# Altium schematic sheet SCM20260D-Ethernet.SchDoc
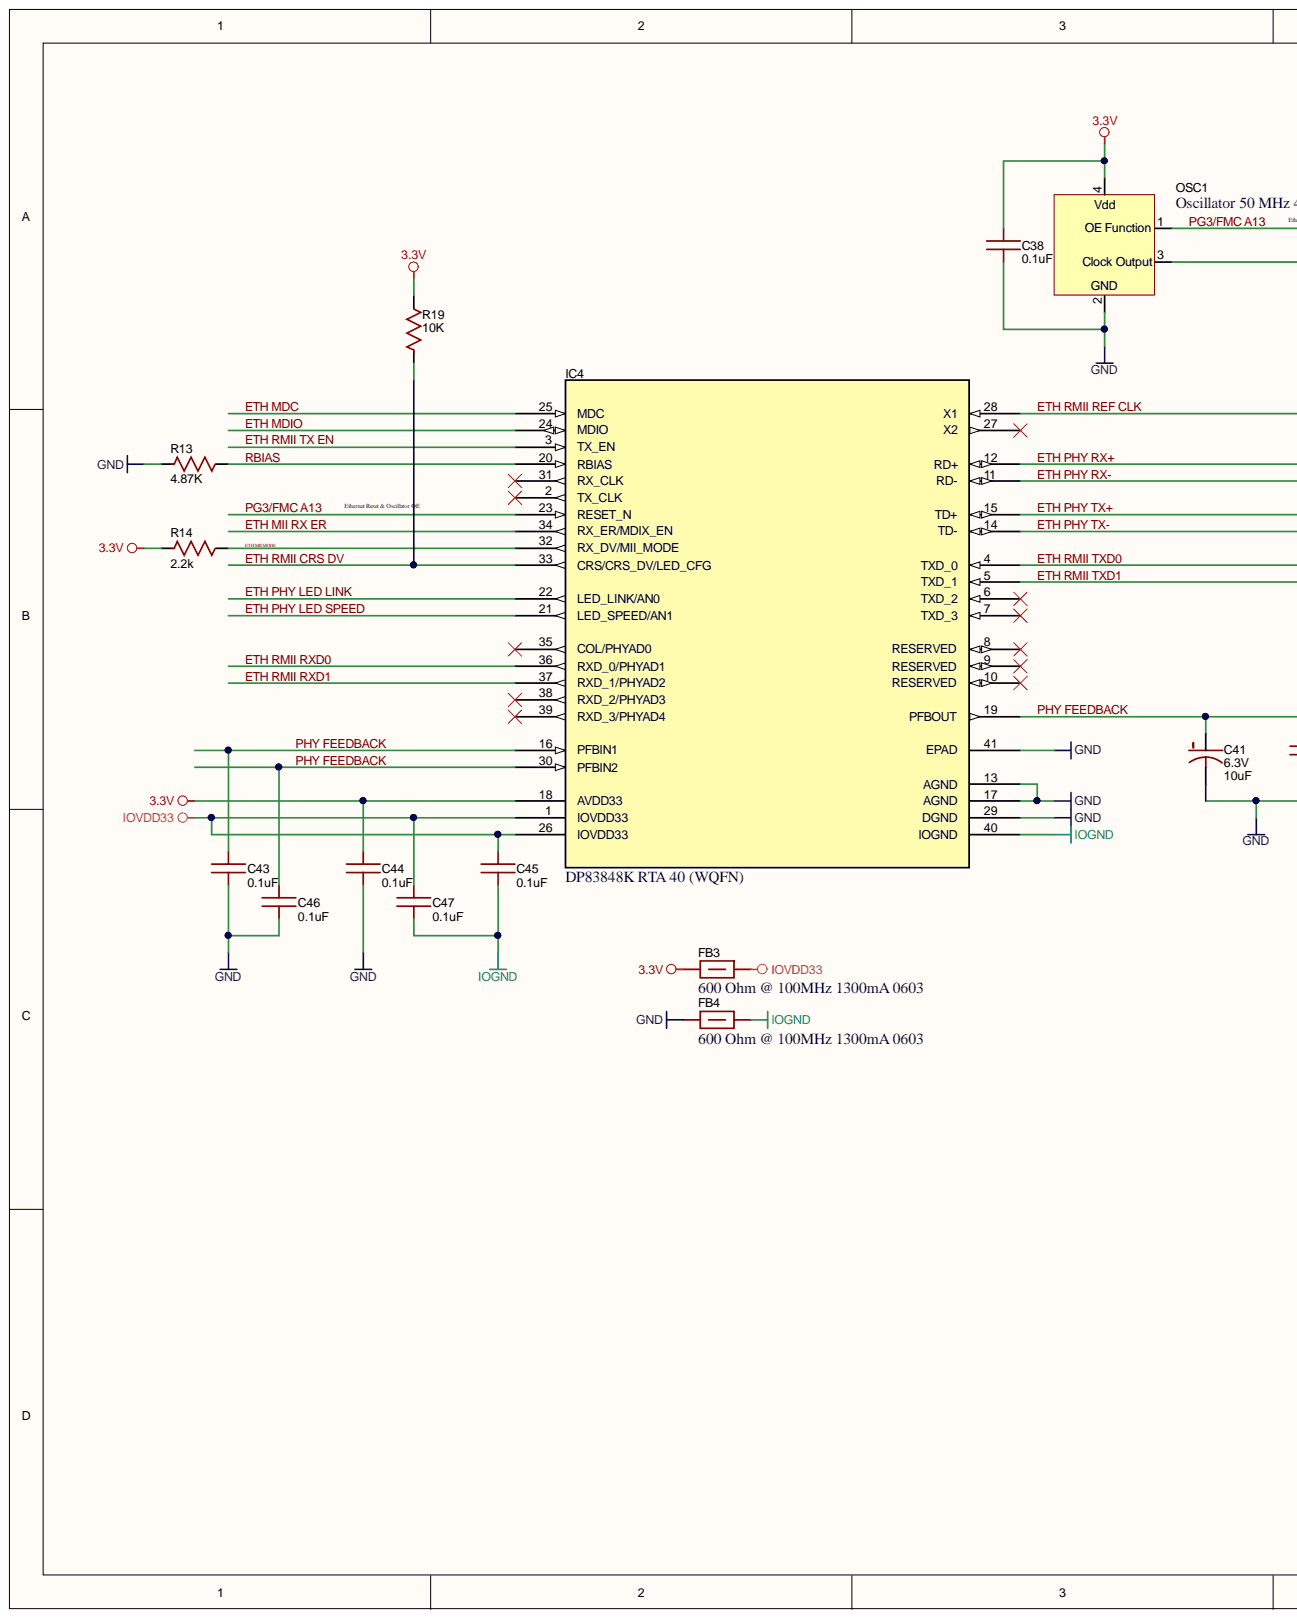
[diagram p0: SCM20260D-Ethernet.SchDoc, left]
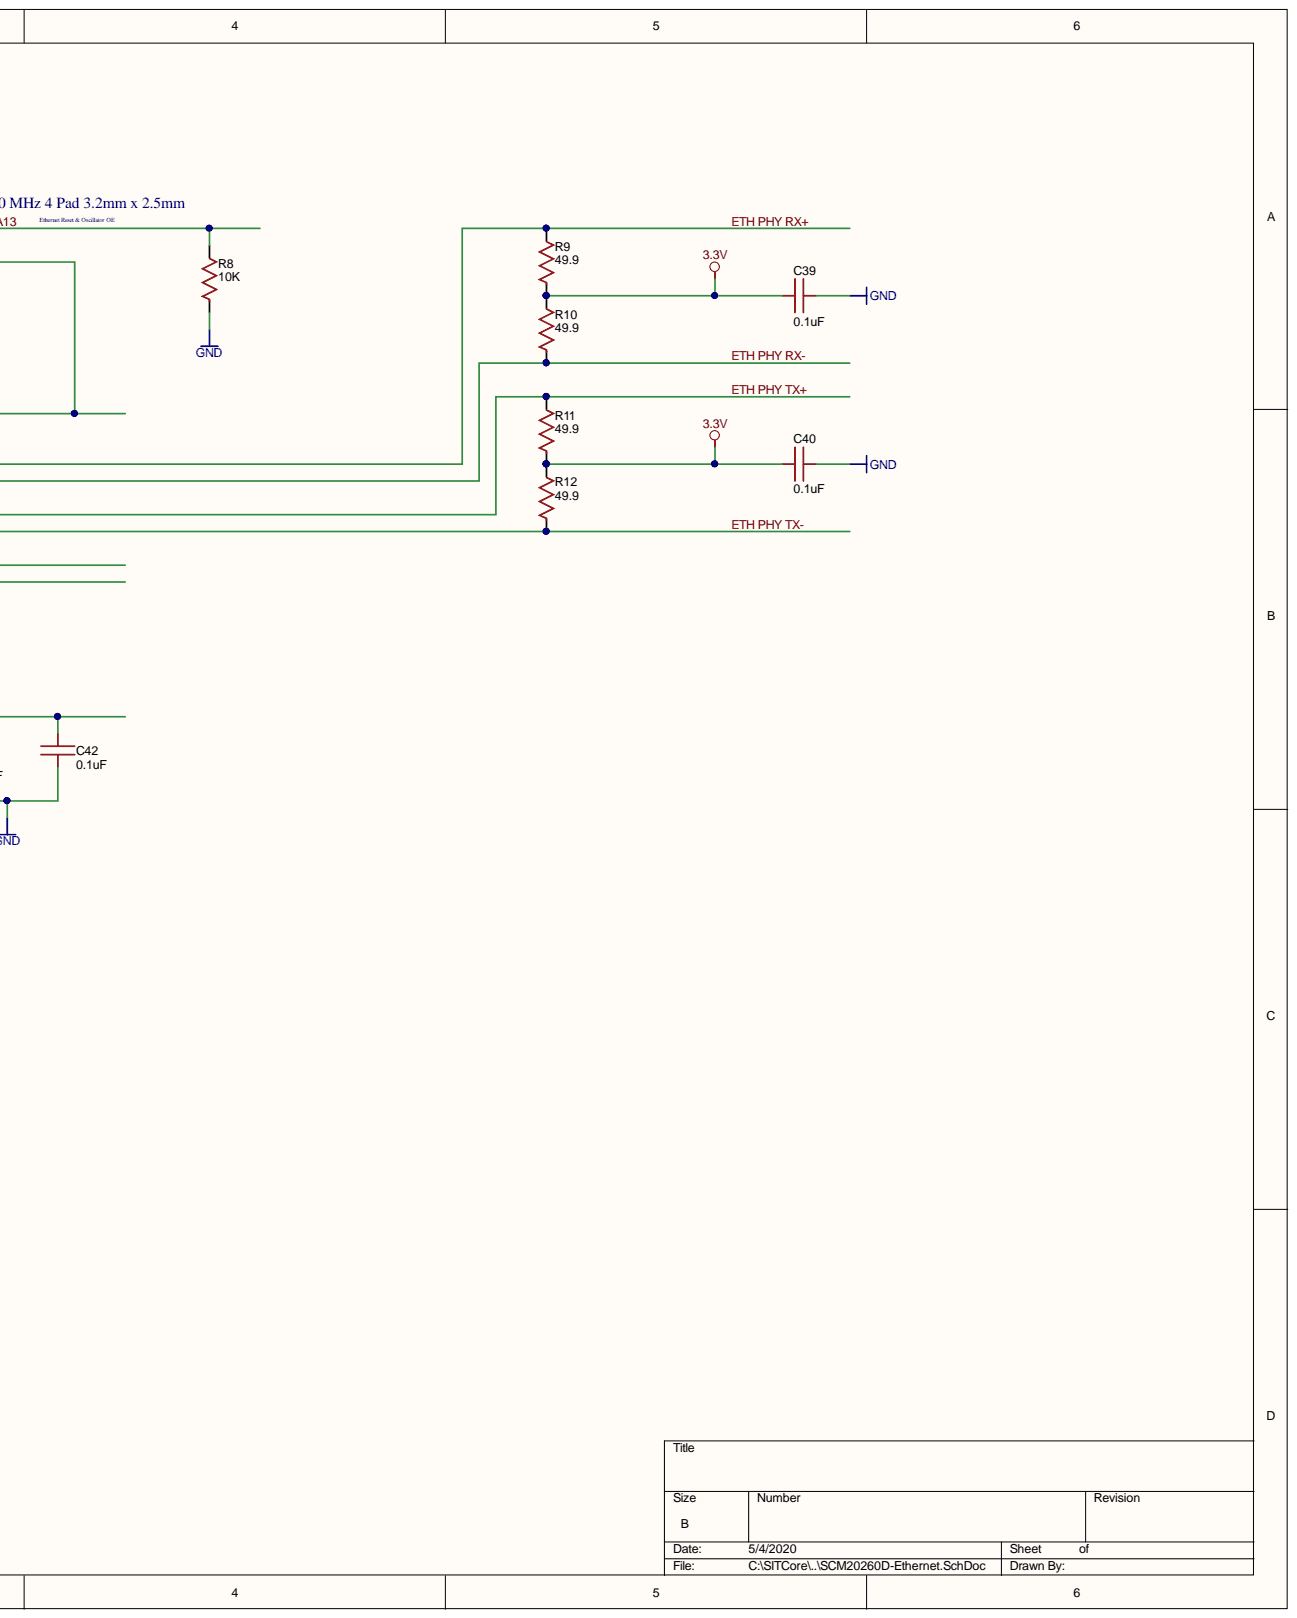
[diagram p1: SCM20260D-Ethernet.SchDoc, right]

## Parts
C38  value 0.1uF  Capacitance: 0.1uF  [C0402]  (hidden comment: 0402 Cap 0.1uF)
C39  value 0.1uF  Capacitance: 0.1uF  [C0402]  (hidden comment: 0402 Cap 0.1uF)
C40  value 0.1uF  Capacitance: 0.1uF  [C0402]  (hidden comment: 0402 Cap 0.1uF)
C41  value 10uF  Capacitance: 10uF  Voltage: 6.3V  [CASE-R 0805 (2012)]  (hidden comment: CASE-R 0805 (2012) Tant 10uF)
C42  value 0.1uF  Capacitance: 0.1uF  [C0402]  (hidden comment: 0402 Cap 0.1uF)
C43  value 0.1uF  Capacitance: 0.1uF  [C0402]  (hidden comment: 0402 Cap 0.1uF)
C44  value 0.1uF  Capacitance: 0.1uF  [C0402]  (hidden comment: 0402 Cap 0.1uF)
C45  value 0.1uF  Capacitance: 0.1uF  [C0402]  (hidden comment: 0402 Cap 0.1uF)
C46  value 0.1uF  Capacitance: 0.1uF  [C0402]  (hidden comment: 0402 Cap 0.1uF)
C47  value 0.1uF  Capacitance: 0.1uF  [C0402]  (hidden comment: 0402 Cap 0.1uF)
FB3  600 Ohm @ 100MHz 1300mA 0603  [R0603 (Metric 1608)]
FB4  600 Ohm @ 100MHz 1300mA 0603  [R0603 (Metric 1608)]
IC4  DP83848K RTA 40 (WQFN)  [WQFN 40 (TI-RTA-40)]
OSC1  Oscillator 50 MHz 4 Pad 3.2mm x 2.5mm  [Crystal 3.2mm x 2.5mm]
R8  value 10K  Resistance: 10K  [R0402]  (hidden comment: 0402 Res 10K)
R9  value 49.9  Resistance: 49.9  [R0402]  (hidden comment: 0402 Res 49.9)
R10  value 49.9  Resistance: 49.9  [R0402]  (hidden comment: 0402 Res 49.9)
R11  value 49.9  Resistance: 49.9  [R0402]  (hidden comment: 0402 Res 49.9)
R12  value 49.9  Resistance: 49.9  [R0402]  (hidden comment: 0402 Res 49.9)
R13  value 4.87K  Resistance: 4.87K  [R0402]  (hidden comment: 0402 Res 4.87K)
R14  value 2.2k  Resistance: 2.2k  [R0402]  (hidden comment: 0402 Res 2.2k)
R19  value 10K  Resistance: 10K  [R0402]  (hidden comment: 0402 Res 10K)

## Nets
3.3V: C38.2 C39.1 C40.1 C44.2 FB3.2 IC4.18(AVDD33) OSC1.4 R9.1 R10.2 R11.1 R12.2 R14.1 R19.2
GND: C38.1 C39.2 C40.2 C41.2 C42.1 C43.1 C44.1 C46.1 FB4.2 IC4.13(AGND) IC4.17(AGND) IC4.29(DGND) IC4.41(EPAD) OSC1.2 R8.1 R13.1
IOGND: C45.1 C47.1 FB4.1 IC4.40(IOGND)
IOVDD33: C45.2 C47.2 FB3.1 IC4.1(IOVDD33) IC4.26(IOVDD33)
ETH MDC: IC4.25(MDC)
ETH MDIO: IC4.24(MDIO)
ETH MII MODE: IC4.32(RX_DV/MII_MODE) R14.2
ETH MII RX ER: IC4.34(RX_ER/MDIX_EN)
ETH PHY LED LINK: IC4.22(LED_LINK/AN0)
ETH PHY LED SPEED: IC4.21(LED_SPEED/AN1)
ETH PHY RX+: IC4.12(RD+) R9.2
ETH PHY RX-: IC4.11(RD-) R10.1
ETH PHY TX+: IC4.15(TD+) R11.2
ETH PHY TX-: IC4.14(TD-) R12.1
ETH RMII CRS DV: IC4.33(CRS/CRS_DV/LED_CFG) R19.1
ETH RMII REF CLK: IC4.28(X1) OSC1.3(Clock_Output)
ETH RMII RXD0: IC4.36(RXD_0/PHYAD1)
ETH RMII RXD1: IC4.37(RXD_1/PHYAD2)
ETH RMII TX EN: IC4.3(TX_EN)
ETH RMII TXD0: IC4.4(TXD_0)
ETH RMII TXD1: IC4.5(TXD_1)
PG3/FMC A13: IC4.23(RESET_N) OSC1.1(OE_Function) R8.2
PHY FEEDBACK: C41.1 C42.2 C43.2 C46.2 IC4.16(PFBIN1) IC4.19(PFBOUT) IC4.30(PFBIN2)
RBIAS: IC4.20(RBIAS) R13.2

Not connected: IC4 2(TX_CLK) 6(TXD_2) 7(TXD_3) 8(RESERVED) 9(RESERVED) 10(RESERVED) 27(X2) 31(RX_CLK) 35(COL/PHYAD0) 38(RXD_2/PHYAD3) 39(RXD_3/PHYAD4)

## Library data of the parts
C38-C40 C42-C47 = 0402 Cap 0.1uF: 0.1uF ±10% 16V X7R 0402 Multilayer Ceramic Capacitors MLCC - SMD/SMT RoHS; Mfr.Part #: CC0402KRX7R7BB104; Category: Ceramic; Component Kind: Standard; LCSC Part #: C60474; Package: 0402; Tolerance: ±10%; Voltage: 16V; Library Name: GHI-Passives.SchLib
C41 = CASE-R 0805 (2012) Tant 10uF: 10uF ±10% 6.3V 6 Ω @ 100kHz -55℃ ~ +125℃ CASE-R_2012 Tantalum Capacitors RoHS; Manufacturer: AVX; Mfr.Part #: TAJR106K006RNJ; Category: Tantalum; Component Kind: Standard; Digikey: 478-3273-2-ND; LCSC Part #: C132274; Package: CASE-N_2012; Tolerance: ±10%; Library Name: GHI-Passives.SchLib
FB3 FB4 = 600 Ohm @ 100MHz 1300mA 0603 (Metric 1608): Ferrite Bead 600 Ohm @ 100MHz 1300mA 0603 (Metric 1608); GHI Part Number: x; Manufacturer Part Number: BLM18KG601SN1D; DC Resistance: 150 mOhm; Impedance @ 100MHz: 600+-25%; Rated Current (mA @ 85C): 1300; Rated Current (mA @ 125C): 1000; Component Kind: Standard; Component Type: Ferrite Bead; Library Name: GHI Basic Components.SchLib; Package: 0603
IC4 = DP83848K RTA 40 (WQFN): TI DP83848K 10/100 Ethernet PHY; Manufacturer Part Number: dp8384k_rta_40; Vendor: Texas Instruments; Component Kind: Standard; RefDes: RefDes; Type: Type; Value: Value; Library Name: GHI IC.SchLib
OSC1 = 50.0000MHz 4 Pad Oscillator 3.2mm x 2.5mm: Oscillator 4 Pad 3.2mm x 2.5mm 50 MHz; GHI Part Number: EPC-Q50MHZ-EMX; Manufacturer Part Number: 6034050000M1503033451; Fan Out Type: TTL/CMOS; Frequency Stability: 50ppm; Output Frequency: 50.000MHz; Supply Voltage: 3.3V; Component Kind: Standard; Library Name: GHI.SchLib; Operating Temperature Range: -40 C to +85 C
R8 R19 = 0402 Res 10K: 10K ±1% 1/16W ±100ppm/℃ 0402 Chip Resistor - Surface Mount RoHS; Manufacturer: YAGEO; Mfr.Part #: RC0402FR-0710KL; Voltage Rating: *V; Component Kind: Standard; LCSC Part #: C60490; Package: 0402; Power Rating: 1/16W; Resistance Tolerance: ±1%; Library Name: GHI-Passives.SchLib
R9-R12 = 0402 Res 49.9: 49.9 ±1% 1/16W ±100ppm/℃ 0402 Chip Resistor - Surface Mount RoHS; Manufacturer: YAGEO; Mfr.Part #: RC0402FR-0749R9L; Voltage Rating: *V; Component Kind: Standard; LCSC Part #: C87044; Package: 0402; Power Rating: 1/16W; Resistance Tolerance: ±1%; Library Name: GHI-Passives.SchLib
R13 = 0402 Res 4.87K: 4.87K ±1% 1/16W ±100ppm/℃ 0402 Chip Resistor - Surface Mount RoHS; Manufacturer: YAGEO; Mfr.Part #: RC0402FR-074K87L; Voltage Rating: *V; Component Kind: Standard; LCSC Part #: C276272; Package: 0402; Power Rating: 1/16W; Resistance Tolerance: ±1%; Library Name: GHI-Passives.SchLib
R14 = 0402 Res 2.2k: 2.2K ±1% 1/16W ±100ppm/℃ 0402 Chip Resistor - Surface Mount RoHS; Manufacturer: YAGEO; Mfr.Part #: RC0402FR-072K2L; Voltage Rating: *V; Component Kind: Standard; LCSC Part #: C114762; Package: 0402; Power Rating: 1/16W; Resistance Tolerance: ±1%; Library Name: GHI-Passives.SchLib
